# Altium schematic sheet LPC2148FBD64_revA.SchDoc
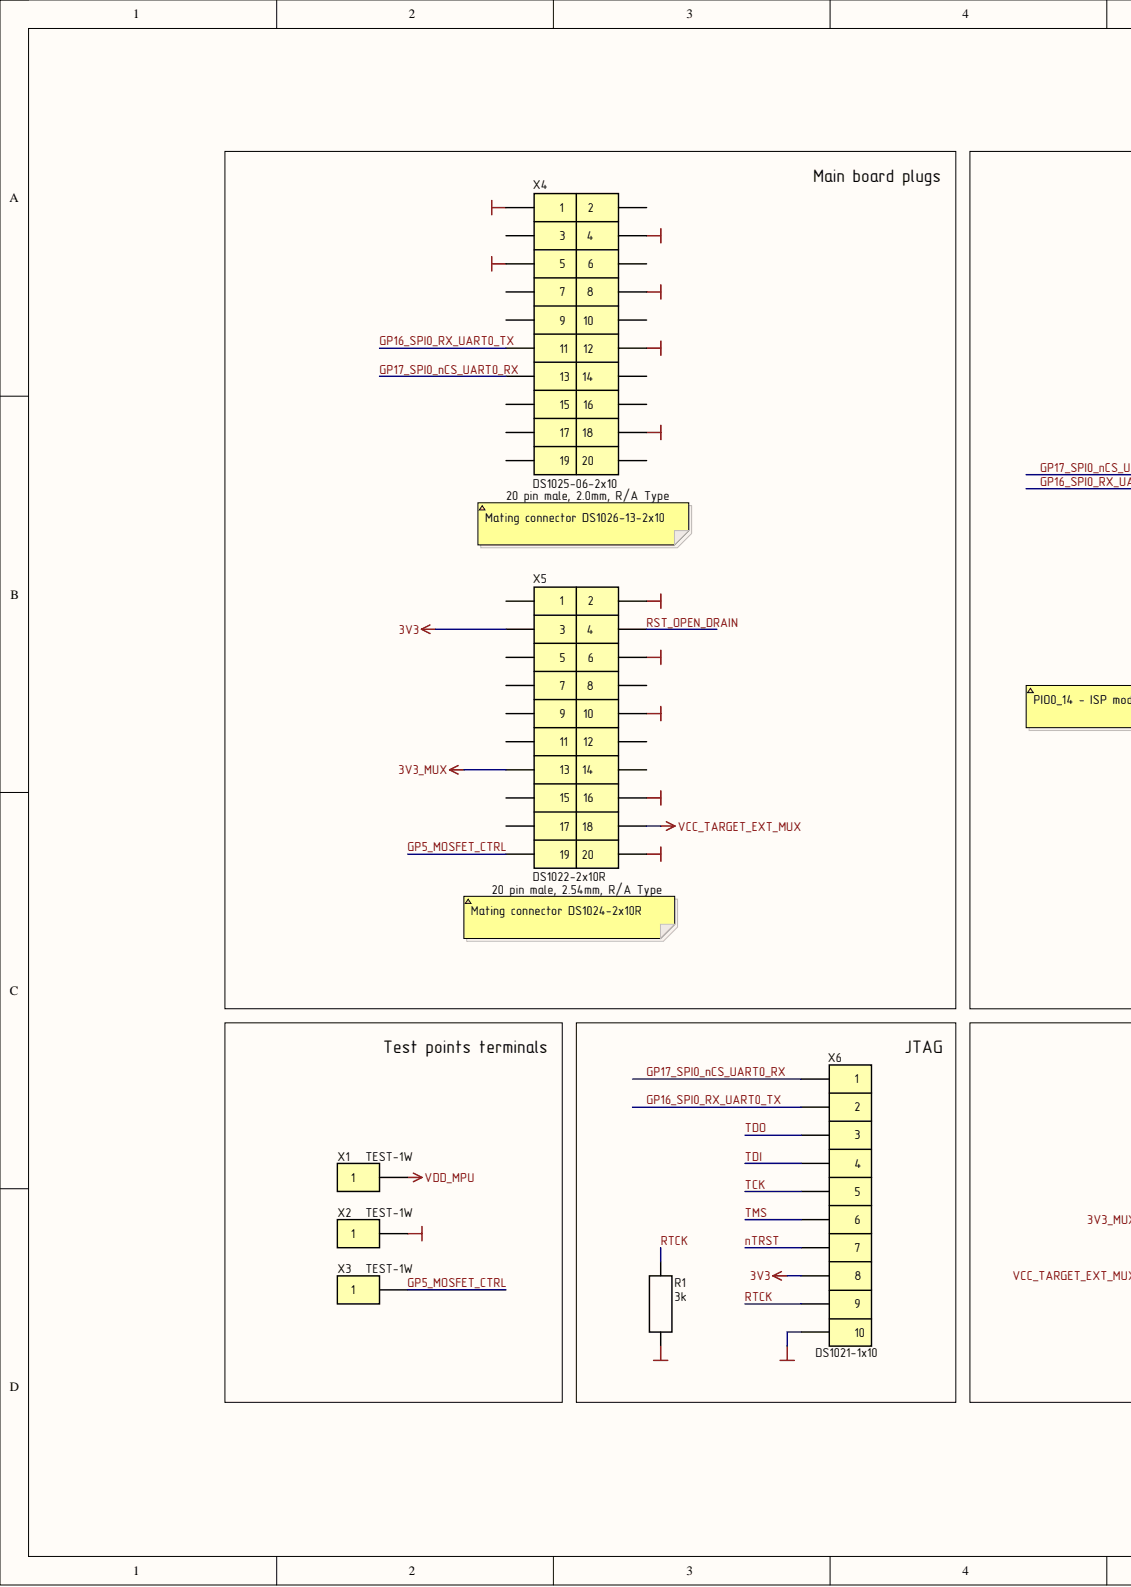
[diagram p0: LPC2148FBD64_revA.SchDoc, left]
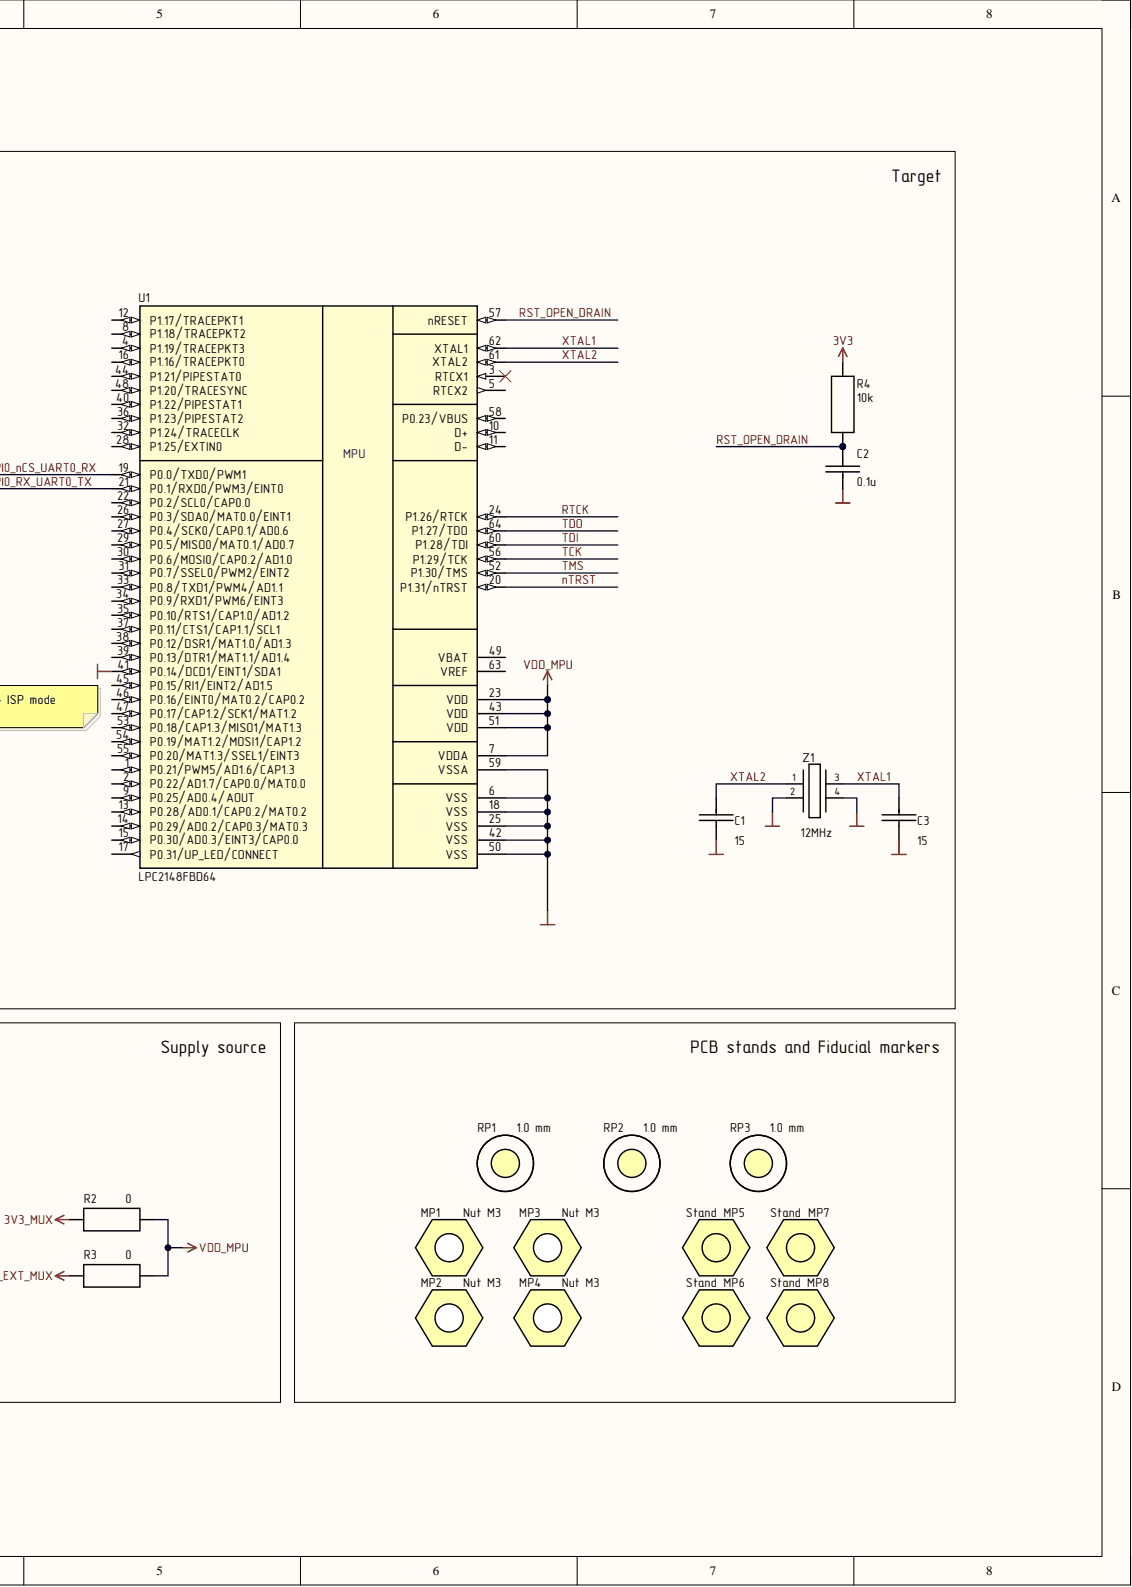
[diagram p1: LPC2148FBD64_revA.SchDoc, right]

## Parts
C1  value 15  [CH0603C]  (hidden comment: Ceramic capacitor, 0603, 15, ±10%, 100V, C0G, -55...+125°C)
C2  value 0.1u  [CH0603C]  (hidden comment: Ceramic capacitor, 0603, 0.1u, ±10%, 50V, X7R, -55...+125°C)
C3  value 15  [CH0603C]  (hidden comment: Ceramic capacitor, 0603, 15, ±10%, 100V, C0G, -55...+125°C)
MP1  Nut M3  [Stand]  (mechanical)
MP2  Nut M3  [Stand]  (mechanical)
MP3  Nut M3  [Stand]  (mechanical)
MP4  Nut M3  [Stand]  (mechanical)
MP5  Stand  [Stand]
MP6  Stand  [Stand]
MP7  Stand  [Stand]
MP8  Stand  [Stand]
R1  value 3k  [CH0603R]  (hidden comment: Resistor thick-film, 0603, 3k, ±5%, 0.1W, -55...+125°C)
R2  value 0  [CH0603R]  (hidden comment: Resistor thick-film, 0603, 0, ±5%, 0.1W, -55...+125°C)
R3  value 0  [CH0603R]  (hidden comment: Resistor thick-film, 0603, 0, ±5%, 0.1W, -55...+125°C)
R4  value 10k  [CH0603R]  (hidden comment: Resistor thick-film, 0603, 10k, ±5%, 0.1W, -55...+125°C)
RP1  1.0 mm  [REPER-10]  (no BOM)
RP2  1.0 mm  [REPER-10]  (no BOM)
RP3  1.0 mm  [REPER-10]  (no BOM)
U1  LPC2148FBD64  [LPC2148FBD64-Footprint-1]
X1  VDD  PartNumber: TEST-1W  [TEST-1W 1pin MULTICOMP]
X2  PartNumber: TEST-1W  [TEST-1W 1pin MULTICOMP]  (hidden comment: GND)
X3  GP5  PartNumber: TEST-1W  [TEST-1W 1pin MULTICOMP]
X4  DS1025-06-2x10  [DS1025-06-2x10]
X5  DS1022-2x10R  [DS1022-2x10R]
X6  PartNumber: DS1021-1x10  [DS1021-01-10  2.54]  (hidden comment: 10-Connector)
Z1  12MHz  [OSC: 3225]

## Nets
3V3: R4.2 X5.3 X6.8
3V3_MUX: R2.2 X5.13
GND: C1.2 C2.1 C3.2 R1.1 U1.6(VSS) U1.18(VSS) U1.25(VSS) U1.41(P0.14/DCD1/EINT1/SDA1) U1.42(VSS) U1.50(VSS) U1.59(VSSA) X2.1 X4.1 X4.4 X4.5 X4.8 X4.12 X4.18 X5.2 X5.6 X5.10 X5.16 X5.20 X6.10 Z1.2 Z1.4
VCC_TARGET_EXT_MUX: R3.2 X5.18
VDD_MPU: R2.1 R3.1 U1.7(VDDA) U1.23(VDD) U1.43(VDD) U1.51(VDD) X1.1
GP5_MOSFET_CTRL: X3.1 X5.19
GP16_SPI0_RX_UART0_TX: U1.21(P0.1/RXD0/PWM3/EINT0) X4.11 X6.2
GP17_SPI0_nCS_UART0_RX: U1.19(P0.0/TXD0/PWM1) X4.13 X6.1
nTRST: U1.20(P1.31/nTRST) X6.7
RST_OPEN_DRAIN: C2.2 R4.1 U1.57(nRESET) X5.4
RTCK: R1.2 U1.24(P1.26/RTCK) X6.9
TCK: U1.56(P1.29/TCK) X6.5
TDI: U1.60(P1.28/TDI) X6.4
TDO: U1.64(P1.27/TDO) X6.3
TMS: U1.52(P1.30/TMS) X6.6
XTAL1: C3.1 U1.62(XTAL1) Z1.3
XTAL2: C1.1 U1.61(XTAL2) Z1.1

Not connected: MP5 1; MP6 1; MP7 1; MP8 1; RP1 1; RP2 1; RP3 1; U1 1(P0.21/PWM5/AD1.6/CAP1.3) 2(P0.22/AD1.7/CAP0.0/MAT0.0) 3(RTCX1) 4(P1.19/TRACEPKT3) 5(RTCX2) 8(P1.18/TRACEPKT2) 9(P0.25/AD0.4/AOUT) 10(D+) 11(D-) 12(P1.17/TRACEPKT1) 13(P0.28/AD0.1/CAP0.2/MAT0.2) 14(P0.29/AD0.2/CAP0.3/MAT0.3) 15(P0.30/AD0.3/EINT3/CAP0.0) 16(P1.16/TRACEPKT0) 17(P0.31/UP_LED/CONNECT) 22(P0.2/SCL0/CAP0.0) 26(P0.3/SDA0/MAT0.0/EINT1) 27(P0.4/SCK0/CAP0.1/AD0.6) 28(P1.25/EXTIN0) 29(P0.5/MISO0/MAT0.1/AD0.7) 30(P0.6/MOSI0/CAP0.2/AD1.0) 31(P0.7/SSEL0/PWM2/EINT2) 32(P1.24/TRACECLK) 33(P0.8/TXD1/PWM4/AD1.1) 34(P0.9/RXD1/PWM6/EINT3) 35(P0.10/RTS1/CAP1.0/AD1.2) 36(P1.23/PIPESTAT2) 37(P0.11/CTS1/CAP1.1/SCL1) 38(P0.12/DSR1/MAT1.0/AD1.3) 39(P0.13/DTR1/MAT1.1/AD1.4) 40(P1.22/PIPESTAT1) 44(P1.21/PIPESTAT0) 45(P0.15/RI1/EINT2/AD1.5) 46(P0.16/EINT0/MAT0.2/CAP0.2) 47(P0.17/CAP1.2/SCK1/MAT1.2) 48(P1.20/TRACESYNC) 49(VBAT) 53(P0.18/CAP1.3/MISO1/MAT1.3) 54(P0.19/MAT1.2/MOSI1/CAP1.2) 55(P0.20/MAT1.3/SSEL1/EINT3) 58(P0.23/VBUS) 63(VREF); X4 2 3 6 7 9 10 14 15 16 17 19 20; X5 1 5 7 8 9 11 12 14 15 17

## Library data of the parts
C1 C3 = Capacitor: Manufacturer: Murata; PartNumber: GRM1885C2A150J; Type: SMD; Component Kind: Standard; ComponentType: Ceramic capacitor; Description/Value: Ceramic capacitor, 0603, 15, ±10%, 100V, C0G, -55...+125°C; HelpURL: ?; Library Name: Common Lib.SchLib; Package: 0603; Temperature: -55...+125°C; Tolerance: ±10%; TypeDielectric: C0G; Voltage: 100V
C2 = Capacitor: Manufacturer: Murata; PartNumber: GRM188R71H104K; Type: SMD; Component Kind: Standard; ComponentType: Ceramic capacitor; Description/Value: Ceramic capacitor, 0603, 0.1u, ±10%, 50V, X7R, -55...+125°C; HelpURL: ?; Library Name: Common Lib.SchLib; Package: 0603; Temperature: -55...+125°C; Tolerance: ±10%; TypeDielectric: X7R; Voltage: 50V
MP1-MP4 = nut: PartNumber: Nut M3 DIN 934; Description/Value: Hexagon Nut M3 DIN 934; Library Name: Common Lib.SchLib
MP5-MP8 = stand: PartNumber: PCHSN-10; Description/Value: PCB brass stand, M3, 10mm; Component Kind: Standard; Library Name: Common Lib.SchLib
R1 = Resistor: Manufacturer: Yageo; PartNumber: RC0603JR-073KL; Component Kind: Standard; ComponentType: Resistor thick-film; Description/Value: Resistor thick-film, 0603, 3k, ±5%, 0.1W, -55...+125°C; HelpURL: https://datasheet.lcsc.com/lcsc/2009261810_YAGEO-RL0805FR-070R33L_C724024.pdf; Library Name: Common Lib.SchLib; Package: 0603; Power: 0.1W; Temperature: -55...+125°C; Tolerance: ±5%; Type: SMD
R2 R3 = Resistor: Manufacturer: Yageo; PartNumber: RC0603JR-070RL; Component Kind: Standard; ComponentType: Resistor thick-film; Description/Value: Resistor thick-film, 0603, 0, ±5%, 0.1W, -55...+125°C; HelpURL: https://datasheet.lcsc.com/lcsc/2009261810_YAGEO-RL0805FR-070R33L_C724024.pdf; Library Name: Common Lib.SchLib; Package: 0603; Power: 0.1W; Temperature: -55...+125°C; Tolerance: ±5%; Type: SMD
R4 = Resistor: Manufacturer: Yageo; PartNumber: RC0603JR-0710KL; Component Kind: Standard; ComponentType: Resistor thick-film; Description/Value: Resistor thick-film, 0603, 10k, ±5%, 0.1W, -55...+125°C; HelpURL: https://datasheet.lcsc.com/lcsc/2009261810_YAGEO-RL0805FR-070R33L_C724024.pdf; Library Name: Common Lib.SchLib; Package: 0603; Power: 0.1W; Temperature: -55...+125°C; Tolerance: ±5%; Type: SMD
RP1-RP3 = CMP-00005-2: Design Item ID: REPER; Source: Common Lib.SchLib; Library Name: Positive Labs Server
U1 = CMP-00089-5: ARM Mikrocontroller - MCU Single-chip 16-bit/32-bit microcontrollers; up to 512 kB flash with ISP/IAP, USB 2.0 full-speed device, 10-bit ADC DAC; Component Kind: Standard; Description/Value: ARM Mikrocontroller - MCU Single-chip 16-bit/32-bit microcontrollers; up to 512 kB flash with ISP/IAP, USB 2.0 full-speed device, 10-bit ADC DAC; Design Item ID: LPC2148FBD64; Package: 48-UFQFPN (7x7); PartNumber: LPC2148FBD64; Source: Common Lib.SchLib; Temperature: -40...+85°C; Type: IC microcontroller; Library Name: Positive Labs Server
X1-X3 = 1-Connector: Description/Value: PCB Test Point Through Hole Mount; Component Kind: Standard; Library Name: Common Lib.SchLib
X4 = 20-Connector: Description/Value: PLD Connector 20 pin, 2.0mm, Male, DS1025-06-2x10; Component Kind: Standard; ComponentKind: PLD Connector 20 pin, 2.0mm, Male; Library Name: Common Lib.SchLib; PartNumber: DS1025-06-2x10
X5 = 20-Connector: Description/Value: PLD Connector 20 pin, 2.54mm, Male, DS1022-2x10R; Component Kind: Standard; ComponentKind: PLD Connector 20 pin, 2.54mm, Male; Library Name: Common Lib.SchLib; PartNumber: DS1022-2x10R
X6 = CMP-00170-2: PLD connector 10 pin, 2.54mm, Male, V/T Type; Description/Value: PLD connector 10 pin, 2.54mm, Male, V/T Type, DS1021-1x10; Component Kind: Standard; Library Name: Positive Labs Server
Z1 = Crystal_3225_25MHz: PartNumber: NX3225GA-12MHZ; Description/Value: Crystal, SMD3225C4, 16MHz; Component Kind: Standard; Library Name: Common Lib.SchLib
